# Altium schematic sheet Умножитель.SchDoc
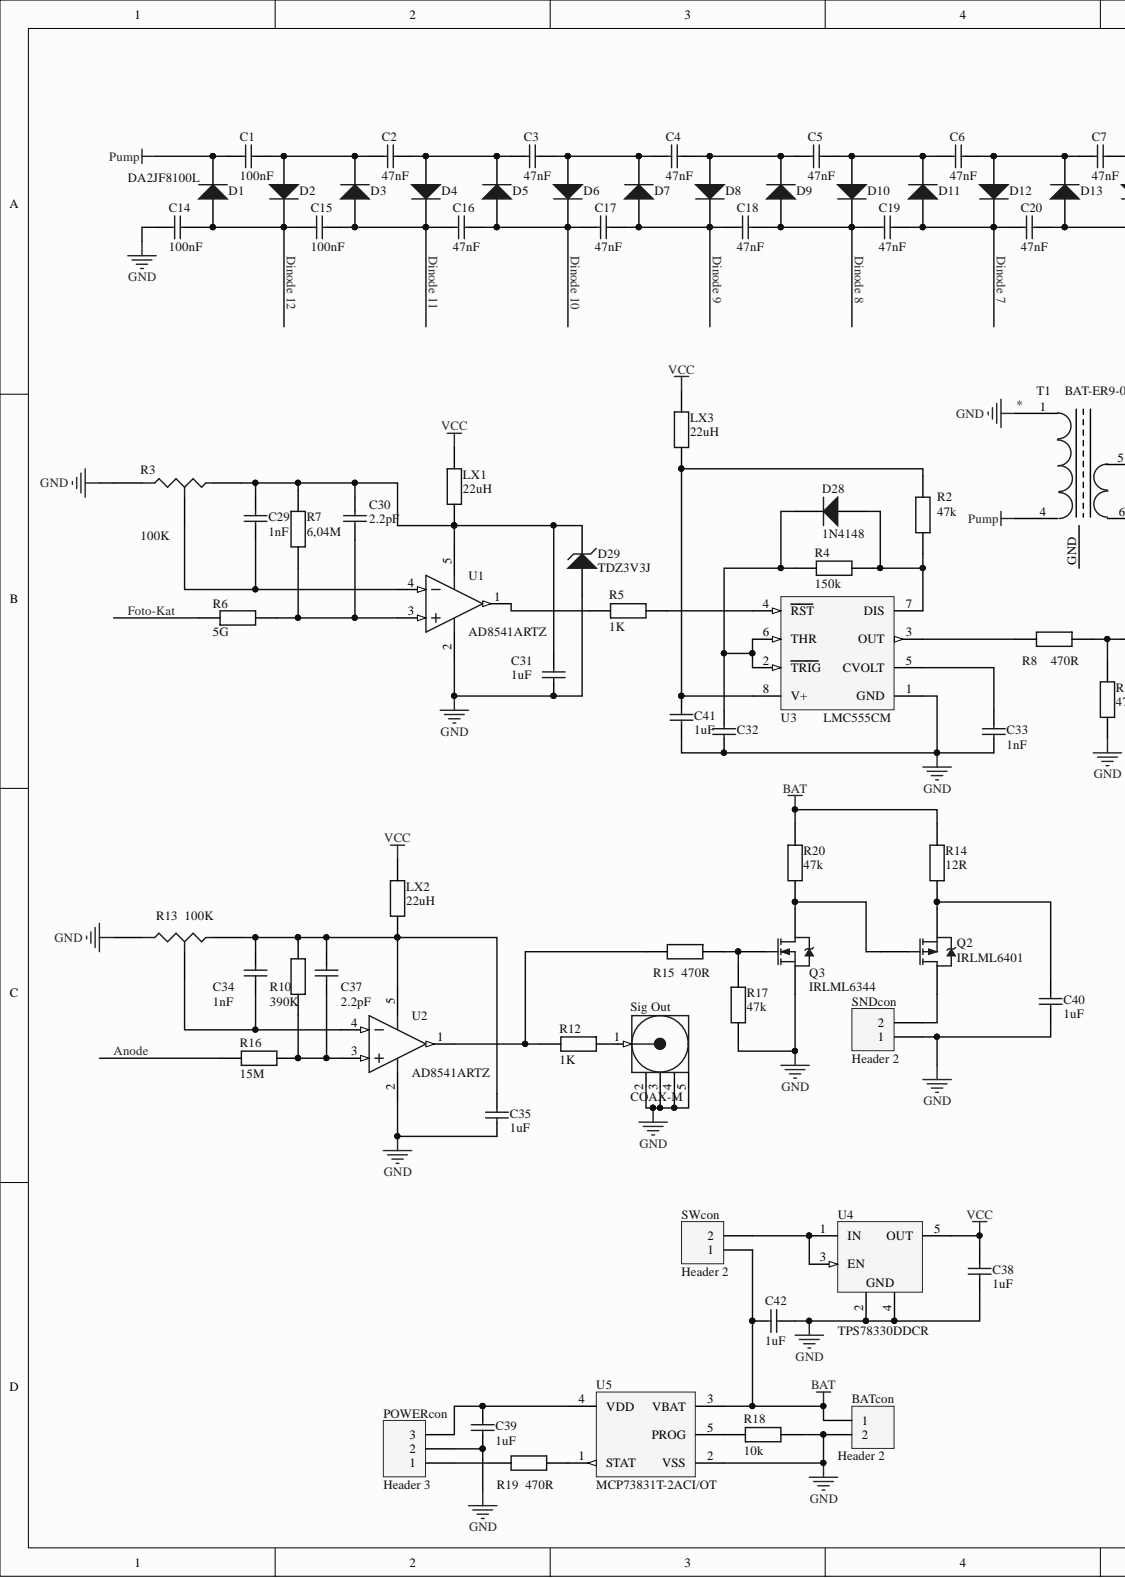
[diagram p0: Умножитель.SchDoc, left]
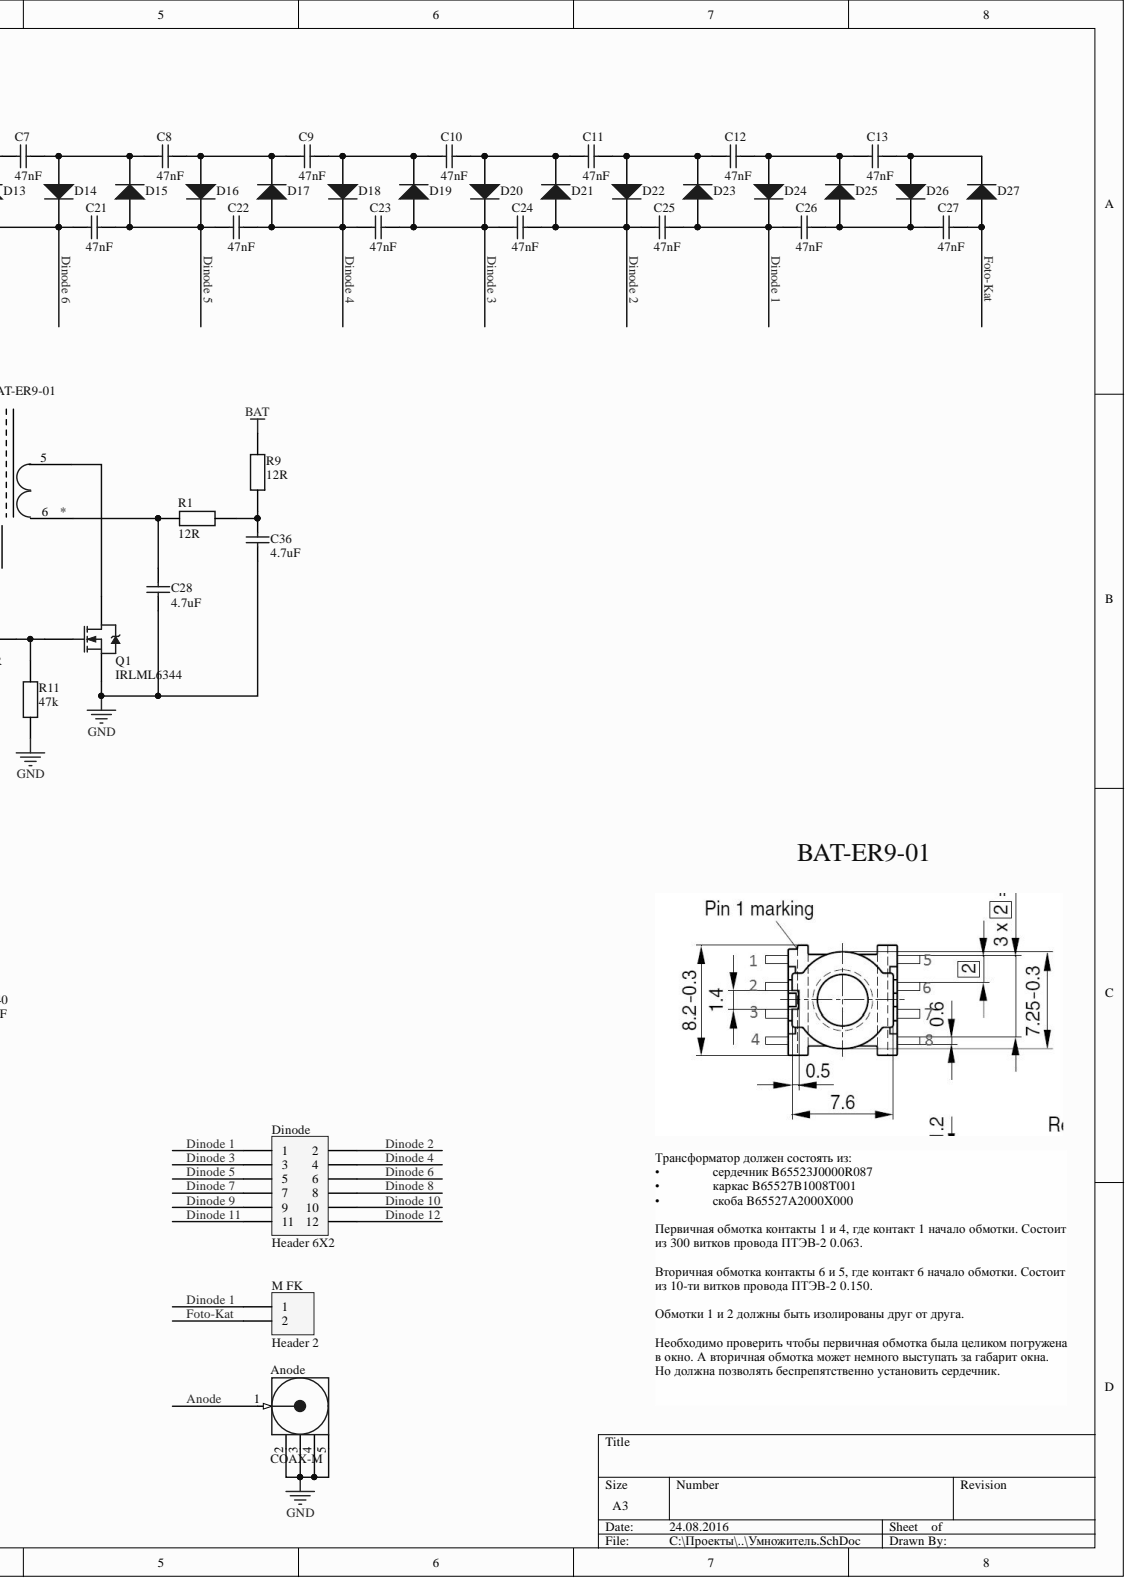
[diagram p1: Умножитель.SchDoc, right]

## Parts
Anode  COAX-M  [MMCX2.54-V5]  (no BOM)
BATcon  Header 2  [LIR2430]
C1  100nF  [C1206]
C2  47nF  [0805_CAP]
C3  47nF  [0805_CAP]
C4  47nF  [0805_CAP]
C5  47nF  [0805_CAP]
C6  47nF  [0805_CAP]
C7  47nF  [0805_CAP]
C8  47nF  [0805_CAP]
C9  47nF  [0805_CAP]
C10  47nF  [0805_CAP]
C11  47nF  [0805_CAP]
C12  47nF  [0805_CAP]
C13  47nF  [0805_CAP]
C14  100nF  [C1206]
C15  100nF  [C1206]
C16  47nF  [0805_CAP]
C17  47nF  [0805_CAP]
C18  47nF  [0805_CAP]
C19  47nF  [0805_CAP]
C20  47nF  [0805_CAP]
C21  47nF  [0805_CAP]
C22  47nF  [0805_CAP]
C23  47nF  [0805_CAP]
C24  47nF  [0805_CAP]
C25  47nF  [0805_CAP]
C26  47nF  [0805_CAP]
C27  47nF  [0805_CAP]
C28  4.7uF  [C1206]
C29  1nF  [1608[0603]_CAP]
C30  2.2pF  [1608[0603]_CAP]
C31  1uF  [1608[0603]_CAP]
C32  220pF  [1608[0603]_CAP]  (value not drawn)
C33  1nF  [1608[0603]_CAP]
C34  1nF  [1608[0603]_CAP]
C35  1uF  [1608[0603]_CAP]
C36  4.7uF  [C1206]
C37  2.2pF  [1608[0603]_CAP]
C38  1uF  [1608[0603]_CAP]
C39  1uF  [1608[0603]_CAP]
C40  1uF  [1608[0603]_CAP]
C41  1uF  [1608[0603]_CAP]
C42  1uF  [1608[0603]_CAP]
D1  DA2JF8100L  [DA2JF8100L]
D2  (no value)  [DA2JF8100L]
D3  (no value)  [DA2JF8100L]
D4  (no value)  [DA2JF8100L]
D5  (no value)  [DA2JF8100L]
D6  (no value)  [DA2JF8100L]
D7  (no value)  [DA2JF8100L]
D8  (no value)  [DA2JF8100L]
D9  (no value)  [DA2JF8100L]
D10  (no value)  [DA2JF8100L]
D11  (no value)  [DA2JF8100L]
D12  (no value)  [DA2JF8100L]
D13  (no value)  [DA2JF8100L]
D14  (no value)  [DA2JF8100L]
D15  (no value)  [DA2JF8100L]
D16  (no value)  [DA2JF8100L]
D17  (no value)  [DA2JF8100L]
D18  (no value)  [DA2JF8100L]
D19  (no value)  [DA2JF8100L]
D20  (no value)  [DA2JF8100L]
D21  (no value)  [DA2JF8100L]
D22  (no value)  [DA2JF8100L]
D23  (no value)  [DA2JF8100L]
D24  (no value)  [DA2JF8100L]
D25  (no value)  [DA2JF8100L]
D26  (no value)  [DA2JF8100L]
D27  (no value)  [DA2JF8100L]
D28  1N4148  [GRZZ0002ZB-A]
D29  TDZ3V3J  [SC-90]
Dinode  Header 6X2  [HDR2X6]  (no BOM)
LX1  22uH  [Resistor]
LX2  22uH  [Resistor]
LX3  22uH  [Resistor]
M_FK  Header 2  [HDR1X2]  (no BOM)
POWERcon  Header 3  [HDR1X3]  (no BOM)
Q1  IRLML6344  [SOT-23_N]
Q2  IRLML6401  [Micro3_N]
Q3  IRLML6344  [SOT-23_N]
R1  12R  [1608[0603]_RES]
R2  47k  [1608[0603]_RES]
R3  100K  [3223W]
R4  150k  [1608[0603]_RES]
R5  1K  [1608[0603]]
R6  5G  [HVF2512T5007FE]
R7  6,04M  [1608[0603]]
R8  470R  [1608[0603]_RES]
R9  12R  [1608[0603]_RES]
R10  390K  [1608[0603]]
R11  47k  [1608[0603]_RES]
R12  1K  [1608[0603]]
R13  100K  [3223W]
R14  12R  [1608[0603]_RES]
R15  470R  [1608[0603]_RES]
R16  15M  [1608[0603]]
R17  47k  [1608[0603]_RES]
R18  10k  [1608[0603]_RES]
R19  470R  [1608[0603]_RES]
R20  47k  [1608[0603]_RES]
Sig_Out  COAX-M  [MMCX2.54-V5]  (no BOM)
SNDcon  Header 2  [HDR1X2]  (no BOM)
SWcon  Header 2  [HDR1X2]  (no BOM)
T1  BAT-ER9-01  [Epcos ER9.5/5]
U1  AD8541ARTZ  [ADI-RJ-5_N]
U2  AD8541ARTZ  [ADI-RJ-5_N]
U3  LMC555CM  [M08A_N]
U4  TPS78330DDCR  [TI-DDC5_N]
U5  MCP73831T-2ACI/OT  [SOT-23-OT5_N]

## Nets
BAT: BATcon.1 C42.2 R9.2 R14.2 R20.1 SWcon.1 U5.3(VBAT)
GND: Anode.2 Anode.3 Anode.4 Anode.5 BATcon.2 C14.2 C28.2 C31.2 C32.1 C33.2 C35.2 C36.2 C38.1 C39.2 C40.2 C41.2 C42.1 D29.1 POWERcon.2 Q1.2 Q3.2 R3.2 R11.1 R13.2 R17.2 R18.2 Sig_Out.2 Sig_Out.3 Sig_Out.4 Sig_Out.5 SNDcon.1 T1.1 U1.2 U2.2 U3.1(GND) U4.2 U4.4 U5.2(VSS)
PUMP: C1.2 D1.2 T1.4
VCC: C38.2 LX1.1 LX2.1 LX3.1 U4.5(OUT)
ANODE: Anode.1 R16.1
DINODE 1: C25.1 C26.2 D23.1 D24.2 Dinode.1 M_FK.1
DINODE 2: C24.1 C25.2 D21.1 D22.2 Dinode.2
DINODE 3: C23.1 C24.2 D19.1 D20.2 Dinode.3
DINODE 4: C22.1 C23.2 D17.1 D18.2 Dinode.4
DINODE 5: C21.1 C22.2 D15.1 D16.2 Dinode.5
DINODE 6: C20.1 C21.2 D13.1 D14.2 Dinode.6
DINODE 7: C19.1 C20.2 D11.1 D12.2 Dinode.7
DINODE 8: C18.1 C19.2 D9.1 D10.2 Dinode.8
DINODE 9: C17.1 C18.2 D7.1 D8.2 Dinode.9
DINODE 10: C16.1 C17.2 D5.1 D6.2 Dinode.10
DINODE 11: C15.1 C16.2 D3.1 D4.2 Dinode.11
DINODE 12: C14.1 C15.2 D1.1 D2.2 Dinode.12
FOTO-KAT: C27.1 D27.1 M_FK.2 R6.1
NetC1_1: C1.1 C2.2 D2.1 D3.2
NetC2_1: C2.1 C3.2 D4.1 D5.2
NetC3_1: C3.1 C4.2 D6.1 D7.2
NetC4_1: C4.1 C5.2 D8.1 D9.2
NetC5_1: C5.1 C6.2 D10.1 D11.2
NetC6_1: C6.1 C7.2 D12.1 D13.2
NetC7_1: C7.1 C8.2 D14.1 D15.2
NetC8_1: C8.1 C9.2 D16.1 D17.2
NetC9_1: C9.1 C10.2 D18.1 D19.2
NetC10_1: C10.1 C11.2 D20.1 D21.2
NetC11_1: C11.1 C12.2 D22.1 D23.2
NetC12_1: C12.1 C13.2 D24.1 D25.2
NetC13_1: C13.1 D26.1 D27.2
NetC26_1: C26.1 C27.2 D25.1 D26.2
NetC28_1: C28.1 R1.1 T1.6
NetC29_1: C29.1 C30.1 C31.1 D29.2 LX1.2 R3.1 R7.1 U1.5
NetC29_2: C29.2 R3.3 U1.4
NetC30_2: C30.2 R6.2 R7.2 U1.3
NetC32_2: C32.2 D28.1 R4.1 U3.2(TRIG) U3.6(THR)
NetC33_1: C33.1 U3.5(CVOLT)
NetC34_1: C34.1 C35.1 C37.1 LX2.2 R10.2 R13.1 U2.5
NetC34_2: C34.2 R13.3 U2.4
NetC36_1: C36.1 R1.2 R9.1
NetC37_2: C37.2 R10.1 R16.2 U2.3
NetC39_1: C39.1 POWERcon.3 U5.4(VDD)
NetC40_1: C40.1 Q2.2 R14.1
NetC41_1: C41.1 LX3.2 R2.2 U3.8(V+)
NetD28_2: D28.2 R2.1 R4.2 U3.7(DIS)
NetPOWERcon_1: POWERcon.1 R19.2
NetQ1_1: Q1.1 R8.1 R11.2
NetQ1_3: Q1.3 T1.5
NetQ2_1: Q2.1 Q3.3 R20.2
NetQ2_3: Q2.3 SNDcon.2
NetQ3_1: Q3.1 R15.1 R17.1
NetR5_1: R5.1 U1.1
NetR5_2: R5.2 U3.4(RST)
NetR8_2: R8.2 U3.3(OUT)
NetR12_1: R12.1 Sig_Out.1
NetR12_2: R12.2 R15.2 U2.1
NetR18_1: R18.1 U5.5(PROG)
NetR19_1: R19.1 U5.1(STAT)
NetSWcon_2: SWcon.2 U4.1(IN) U4.3(EN)

Not connected: T1 GND

## Library data of the parts
Anode Sig_Out = COAX-M: RF Coaxial PCB Connector, MMCX; Thru-Hole, Vertical Mount Plug,  50 Ohm Impedance; DrillSize: Corresponds to nominal value.; PackageDocument: Rev. A, 16-Jun-1997; PCB_Layout: Complies with manufacturer's recommendation.; ComponentLink1Description: Manufacturer Link; ComponentLink1URL: http://www.amphenolrf.com/; DatasheetDocument: 30-May-2003; LatestRevisionDate: 15-Jul-2004; LatestRevisionNote: Component Replaced.; Library Name: Miscellaneous Connectors.IntLib; PackageReference: 908-21103; Published: 15-Jul-2004; Publisher: Altium Limited
BATcon M_FK SNDcon SWcon = Header 2: Header, 2-Pin; LatestRevisionDate: 17-Jul-2002; LatestRevisionNote: Re-released for DXP Platform.; Library Name: Miscellaneous Connectors.IntLib; Signal Integrity: Connector; Publisher: Altium Limited
  BATcon: Component Kind: Standard; Order: LIR2430
C1 C14 C15 = Cap Semi: Capacitor (Semiconductor SIM Model); Order: CGA5L4X7T2W104K; Component Kind: Standard; LatestRevisionDate: 29-May-2009; LatestRevisionNote: IPC-7351 Footprint Added.; Library Name: Miscellaneous Devices.IntLib; PackageDescription: Chip Capacitor; PackageReference: C1206; Published: 8-Jun-2000; Signal Integrity: Cap Semi; Simulation: CAP; Value: 100nF; Publisher: Altium Limited
C2-C13 C16-C27 = Cap Semi: Capacitor (Semiconductor SIM Model); Order: CGA4J4X7T2W473M/SOFT; Component Kind: Standard; LatestRevisionDate: 29-May-2009; LatestRevisionNote: IPC-7351 Footprint Added.; Library Name: Miscellaneous Devices.IntLib; PackageDescription: Chip Capacitor; PackageReference: C1206; Published: 8-Jun-2000; Signal Integrity: Cap Semi; Simulation: CAP; Value: 47nF; Publisher: Altium Limited
C28 C36 = Cap Semi: Capacitor (Semiconductor SIM Model); Order: CC1206KKX7R8BB475; Component Kind: Standard; LatestRevisionDate: 29-May-2009; LatestRevisionNote: IPC-7351 Footprint Added.; Library Name: Miscellaneous Devices.IntLib; PackageDescription: Chip Capacitor; PackageReference: C1206; Published: 8-Jun-2000; Signal Integrity: Cap Semi; Simulation: CAP; Value: 4.7uF; Publisher: Altium Limited
C29 C33 C34 = Cap Semi: Capacitor (Semiconductor SIM Model); Order: CC0603JRNPO9BN102; Component Kind: Standard; LatestRevisionDate: 29-May-2009; LatestRevisionNote: IPC-7351 Footprint Added.; Library Name: Miscellaneous Devices.IntLib; PackageDescription: Chip Capacitor; Published: 8-Jun-2000; Signal Integrity: Cap Semi; Simulation: CAP; Value: 1nF; Publisher: Altium Limited
  C29 C34: PackageReference: C0402
  C33: PackageReference: C1206
C30 C37 = Cap Semi: Capacitor (Semiconductor SIM Model); Order: CC0603CRNPO9BN2R2; Component Kind: Standard; LatestRevisionDate: 29-May-2009; LatestRevisionNote: IPC-7351 Footprint Added.; Library Name: Miscellaneous Devices.IntLib; PackageDescription: Chip Capacitor; PackageReference: C0402; Published: 8-Jun-2000; Signal Integrity: Cap Semi; Simulation: CAP; Value: 2.2pF; Publisher: Altium Limited
C31 C35 C38-C42 = Cap Semi: Capacitor (Semiconductor SIM Model); Order: CC0603KRX5R7BB105; Component Kind: Standard; LatestRevisionDate: 29-May-2009; LatestRevisionNote: IPC-7351 Footprint Added.; Library Name: Miscellaneous Devices.IntLib; PackageDescription: Chip Capacitor; Published: 8-Jun-2000; Signal Integrity: Cap Semi; Simulation: CAP; Value: 1uF; Publisher: Altium Limited
  C31 C35: PackageReference: C1206
  C38-C42: PackageReference: C0402
C32 = Cap Semi: Capacitor (Semiconductor SIM Model); Order: CC0603JRNPO9BN221; Component Kind: Standard; LatestRevisionDate: 29-May-2009; LatestRevisionNote: IPC-7351 Footprint Added.; Library Name: Miscellaneous Devices.IntLib; PackageDescription: Chip Capacitor; PackageReference: C1206; Published: 8-Jun-2000; Signal Integrity: Cap Semi; Simulation: CAP; Value: 220pF; Publisher: Altium Limited
D1 = Diode: Default Diode; Code_JEDEC: DO-214-AB; Component Kind: Standard; LatestRevisionDate: 17-Jul-2002; LatestRevisionNote: Re-released for DXP Platform.; Library Name: Miscellaneous Devices.IntLib; Order: DA2JF8100L; PackageDescription: DO-214-AB/SMC; 2 C-Bend Leads; Body 7.9 x 5.9 mm, inc. leads (LxW); PackageReference: SMC; PackageVersion: Sep-1996; Published: 8-Jun-2000; Signal Integrity: Diode; Simulation: DIODE; Value: DA2JF8100L; Publisher: Altium Limited
D2-D27 = Diode: Default Diode; Value: DA2JF8100L; Code_JEDEC: DO-214-AB; Component Kind: Standard; LatestRevisionDate: 17-Jul-2002; LatestRevisionNote: Re-released for DXP Platform.; Library Name: Miscellaneous Devices.IntLib; Order: DA2JF8100L; PackageDescription: DO-214-AB/SMC; 2 C-Bend Leads; Body 7.9 x 5.9 mm, inc. leads (LxW); PackageReference: SMC; PackageVersion: Sep-1996; Published: 8-Jun-2000; Signal Integrity: Diode; Simulation: DIODE; Publisher: Altium Limited
D28 = 1N4148: Silicon Epitaxial Planar Diode for Various Detector, Modulator, Demodulator; Code_JEITA: SC-40; Component Kind: Standard; ComponentLink1Description: Manufacturer Link; ComponentLink1URL: http://sg.renesas.com/fmwk.jsp?cnt=diode_landing.jsp&fp=/products/discrete/diodes/; ComponentLink2Description: Datasheet; ComponentLink2URL: http://documentation.renesas.com/eng/products/diode/rej03g0556_1n4148.pdf; ComponentLink3Description: Packaging Specification; ComponentLink3URL: http://sg.renesas.com/media/products/common_info/package/pdf/diode/code/grzz0002zb_a.pdf; DatasheetVersion: Rev.5.00, Jul-2006; Library Name: Renesas Diode.IntLib; Order: 1N4148; PackageDescription: Diode, Axial; Body 4.2 x 2.0 mm (LxDia.), Lead Dia. 0.5 mm (nom); PackageReference: GRZZ0002ZB-A; PackageVersion: Aug-2005; Published: 12-Feb-2010; Signal Integrity: 1N4148; Publisher: Altium Limited
D29 = D Zener: Zener Diode; Component Kind: Standard; LatestRevisionDate: 17-Jul-2002; LatestRevisionNote: Re-released for DXP Platform.; Library Name: Miscellaneous Devices.IntLib; Order: TDZ3V3J; PackageDescription: Diode, Thru-Hole; 2 Leads; 0.7 in Pin Spacing; PackageReference: DIODE-0.7; Published: 8-Jun-2000; Signal Integrity: D Zener; Simulation: ZENER; Publisher: Altium Limited
Dinode = Header 6X2: Header, 6-Pin, Dual row; LatestRevisionDate: 17-Jul-2002; LatestRevisionNote: Re-released for DXP Platform.; Library Name: Miscellaneous Connectors.IntLib; Signal Integrity: Connector; Publisher: Altium Limited
LX1-LX3 = Resistor 0805: Order: LQM21FN220N00L; Component Kind: Standard; Value: 22uH; Library Name: Микрон-3.SchLib
POWERcon = Header 3: Header, 3-Pin; LatestRevisionDate: 17-Jul-2002; LatestRevisionNote: Re-released for DXP Platform.; Library Name: Miscellaneous Connectors.IntLib; Signal Integrity: Connector; Publisher: Altium Limited
Q1 Q3 = IRLML2402: HEXFET Power MOSFET; Component Kind: Standard; ComponentLink1Description: Manufacturer Link; ComponentLink1URL: http://www.irf.com/; ComponentLink2Description: Datasheet; ComponentLink2URL: http://www.irf.com/product-info/datasheets/data/irlml2402.pdf; DatasheetVersion: Aug-1997; LatestRevisionDate: 20-Nov-2009; LatestRevisionNote: IPC-7351 Footprint Added.; Library Name: IR Discrete MOSFET - Power.IntLib; Order: IRLML6344; PackageDescription: 3-Pin SOT-23 Package 0.95 mm Pitch, 2.3 mm Lead Span; PackageReference: SOT-23; Published: 8-Jun-2000; Simulation: IRLML2402; Publisher: Altium Limited
Q2 = IRLML6401: HEXFET Power MOSFET; Component Kind: Standard; ComponentLink1Description: Manufacturer Link; ComponentLink1URL: http://www.irf.com/; ComponentLink2Description: Datasheet; ComponentLink2URL: http://www.irf.com/product-info/datasheets/data/IRLML6401.pdf; DatasheetVersion: 2-Jul-2001; Library Name: IR Discrete MOSFET - Power.IntLib; Order: IRLML6401; PackageDescription: 3-Pin SOT-23 Package 0.95 mm Pitch, 2.3 mm Lead Span; PackageReference: Micro3; PackageVersion: Jul-2001; Published: 17-Sep-2003; Simulation: IRLML6401; Publisher: Altium Limited
R1 R9 R14 = Resistor 0805: Avail: 20; Order: ERJ3GEYJ120V; Component Kind: Standard; Value: 12R; Library Name: Микрон-3.SchLib
R2 R11 R17 R20 = Resistor 0805: Avail: 20; Order: RC0603FR-0747KL; Component Kind: Standard; Value: 47k; Library Name: Микрон-3.SchLib
R3 R13 = Res Tap: Tapped Resistor; Order: 3223W-1-104E; Set Position: 0.5; Sim Note: Enter the Resistance value and the set point into parameter fields.; Component Kind: Standard; LatestRevisionDate: 17-Jul-2002; LatestRevisionNote: Re-released for DXP Platform.; Library Name: Miscellaneous Devices.IntLib; PackageDescription: Variable Resistor, Thru-Hole; 3 Leads; PackageReference: VR3; Published: 24-Mar-1999; Signal Integrity: Res Tap; Simulation: POT; Value: 100K; Publisher: Altium Limited
R4 = Resistor 0805: Avail: 20; Order: RC0603FR-07150KL; Component Kind: Standard; Value: 150k; Library Name: Микрон-3.SchLib
R5 R12 = Resistor 0805: Order: RC0603FR-101KL; Component Kind: Standard; Value: 1K; Library Name: Микрон-3.SchLib
R6 = Resistor 0805: Component Kind: Standard; Order: HVF2512T5007FE; Value: 5G; Library Name: Микрон-3.SchLib
R7 = Resistor 0805: Order: CRCW06036M04FKEA; Component Kind: Standard; Value: 6,04M; Library Name: Микрон-3.SchLib
R8 R15 R19 = Resistor 0805: Order: RC0603JR-07470RL; Component Kind: Standard; Value: 470R; Library Name: Микрон-3.SchLib
R10 = Resistor 0805: Order: RC0603FR-07390KL; Component Kind: Standard; Value: 390K; Library Name: Микрон-3.SchLib
R16 = Resistor 0805: Order: RC0603JR-0715ML; Component Kind: Standard; Value: 15M; Library Name: Микрон-3.SchLib
R18 = Resistor 0805: Avail: 20; Order: RC0603FR-1010KL; Component Kind: Standard; Value: 10k; Library Name: Микрон-3.SchLib
T1 = Epcos ER9.5/5: Component Kind: Standard; Library Name: My SCH library.SchLib; Order: BAT-ER9-01
U1 U2 = AD8541ARTZ-R2: Single Channel RRIO Operational Amplifier, 1 MHz BW, 920 mV/us SR, Industrial, 5-pin SOT23 (RJ-5), Reel; Generic Part Number: AD8541; Manufacturer: Analog Devices; Amplifiers Per Package: 1; Input Bias Current: 4pA; Input Offset Voltage: 1mV; Iq/Amp: 65uA; Small Signal Bandwidth: 1MHz; V Span Max: 5.5V; V Span Min: 2.7V; Code_JEDEC: MO-178-AA; Component Kind: Standard; ComponentLink1Description: Manufacturer URL; ComponentLink1URL: http://www.analog.com/en/index.html; ComponentLink2Description: Datasheet; ComponentLink2URL: http://www.analog.com/static/imported-files/data_sheets/AD8541_8542_8544.pdf; ComponentLink3Description: Package Specification; ComponentLink3URL: http://www.analog.com/static/imported-files/packages/PKG_PDF/SOT-23(RJ)/RJ_5.pdf; ComponentLink4Description: Reference Circuit; ComponentLink4URL: http://www.analog.com/en/all-operational-amplifiers-op-amps/operational-amplifiers-op-amps/ad8541/products/product.html#product-recommendations; DatasheetVersion: Rev. G; Library Name: Analog Devices Operational Amplifiers.IntLib; Mounting Technology: Surface Mount; Order: AD8541ARTZ; PackageDescription: 5-Pin Small Outline Transistor Package, Body 2.9 x 1.6 mm, Pitch 0.95 mm; PackageReference: RJ-5; PackageVersion: 121608-A; PartNumber: AD8541ARTZ-R2; Slew Rate: 920mV/us; Supplier 1: Digi-Key; Supplier 2: Digi-Key; Supplier 3: Digi-Key; Supplier 4: Farnell; Supplier 5: Newark; Supplier Part Number 1: AD8541ARTZ-R2TR-ND; Supplier Part Number 2: AD8541ARTZ-R2CT-ND; Supplier Part Number 3: AD8541ARTZ-R2DKR-ND; Supplier Part Number 4: 1837434; Supplier Part Number 5: 59K6820
U3 = LMC555CM: CMOS Timer, 8-pin Narrow SOIC; Manufacturer: National Semiconductor; CompType: Analog IC; PackageTopMarking: NSZXTT*LMC*555CM; PowerWise: N; Code_IPC: SOIC127P600-8; Code_JEDEC: MS-012-AA; Component Kind: Standard; ComponentLink1Description: LMC555 Datasheet; ComponentLink1URL: http://www.national.com/ds/LM/LMC555.pdf; ComponentLink2Description: LMC555 Web Page; ComponentLink2URL: http://www.national.com/pf/LM/LMC555.html; ComponentLink3Description: M08A Package Page; ComponentLink3URL: http://www.national.com/packaging/folders/m08a.html; DatasheetVersion: May-2006; Library Name: NSC Analog.IntLib; Order: LMC555CM; PackageDescription: SOIC Narrow, 4.9 x 3.9 x 1.45mm, 8 Lead, 1.27mm Pitch; PackageReference: M08A; PackageVersion: revM, May-2009; PartNumber: LMC555CM; PinCount: 8; Published: 4-Dec-2008; RoHS: N; Supplier 1: Farnell; Supplier Part Number 1: 9493972; Publisher: Altium Limited
U4 = TPS78330DDCR: Single Output LDO, 150 mA, Fixed 3 V Output, 2.2 to 5.5 V Input, with Ultra-Low IQ, 5-pin SOT (DDC), -40 to 105 degC, Green (RoHS & no Sb/Br); Manufacturer: Texas Instruments; Accuracy(%): 3; Iout(Max)(A): 0.15; Iq(Typ)(mA): 0.0005; Rating: Catalog; SubFamily: Single Channel LDO; Vdo(Typ)(mV): 130; Vin(Max)(V): 5.5; Vin(Min)(V): 2.2; Code_JEDEC: MO-193; Component Kind: Standard; ComponentLink1Description: Manufacturer URL; ComponentLink1URL: http://www.ti.com/; ComponentLink2Description: Datasheet; ComponentLink2URL: http://www.ti.com/general/docs/lit/getliterature.tsp?genericPartNumber=TPS78330&fileType=pdf; ComponentLink3Description: Package Specification; ComponentLink3URL: http://www.ti.com/litv/pdf/mpds123d; DatasheetVersion: SBVS133; Eco-Plan: Green (RoHS & no Sb/Br); Fixed Output Options(V): 3; Library Name: TI Power Management Linear Regulator Single Channel LDO (0-350mA).IntLib; Mounting Technology: Surface Mount; Order: TPS78330DDCR; PackageDescription: 5-Pin Plastic Small Outline, Body 2.9 x 1.6 mm, 0.95 mm Pitch; PackageReference: DDC5; PackageVersion: 4204403-2/E; PartNumber: TPS78330DDCR; Supplier 1: Digi-Key; Supplier 2: Digi-Key; Supplier 3: Digi-Key; Supplier 4: Newark; Supplier 5: Mouser; Supplier Part Number 1: 296-25880-2-ND; Supplier Part Number 2: 296-25880-1-ND; Supplier Part Number 3: 296-25880-6-ND; Supplier Part Number 4: 72R6681; Supplier Part Number 5: 595-TPS78330DDCR; Vout Adj(Max)(V): 3; Vout Adj(Min)(V): 3
U5 = MCP73831T-2ACI/OT: Miniature Single-Cell, Fully Integrated Li-Ion, Li-Polymer Charge Management Controller, 4.2V, 5-Pin SOT-23, Industrial Temperature, Tape and Reel; Code_IPC: SOT23-5; Component Kind: Standard; ComponentLink1Description: Datasheet; ComponentLink1URL: http://ww1.microchip.com/downloads/en/DeviceDoc/21984e.pdf; ComponentLink2Description: Packaging Specification; ComponentLink2URL: http://www.microchip.com/stellent/groups/techpub_sg/documents/packagingspec/en012702.pdf; ComponentLink3Description: Microchip.com Home; ComponentLink3URL: http://www.microchip.com/; DatasheetVersion: revE, Sep-2008; LatestRevisionDate: 27-Nov-2009; LatestRevisionNote: Updated to datasheet revision E, Sep-2008; Library Name: Microchip Power Mgt Battery Management.IntLib; Order: MCP73831T-2ACI/OT; PackageDescription: 5-Lead Plastic Small Outline Transistor (OT) [SOT-23]; PackageReference: SOT-23-OT5; PackageVersion: revBB, Aug-2009; Published: 29-Jan-2009; Supplier 1: Digi-Key; Supplier 2: Digi-Key; Supplier 3: Digi-Key; Supplier 4: Farnell; Supplier Part Number 1: MCP73831T-2ACI/OTTR-ND; Supplier Part Number 2: MCP73831T-2ACI/OTCT-ND; Supplier Part Number 3: MCP73831T-2ACI/OTDKR-ND; Supplier Part Number 4: 1332158; Publisher: Altium Limited
